# Altium schematic sheet Voltage Regulator.SchDoc
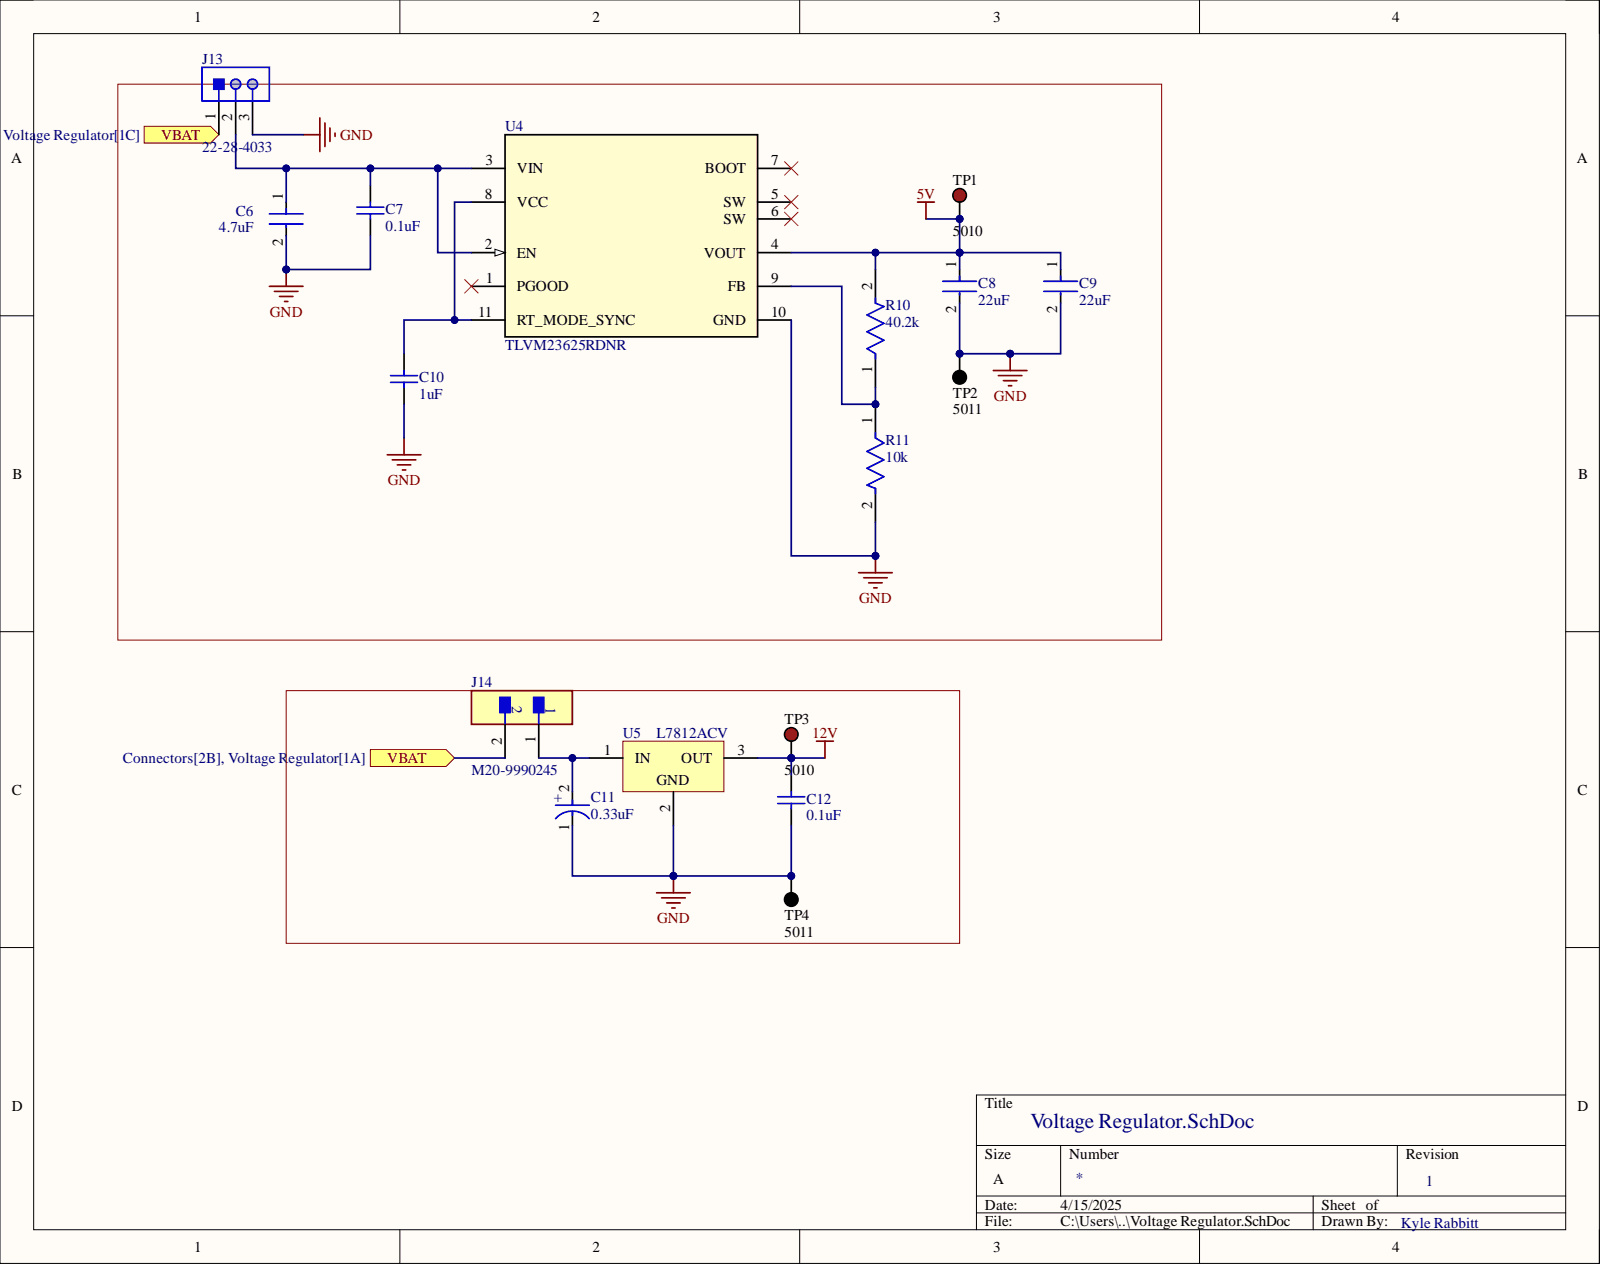
[diagram p0: Voltage Regulator.SchDoc]

## Parts
C6  4.7uF  [FP-C1210X475K5RACTU-MFG]
C7  0.1uF  [CAPC1608X90X35ML10T15]
C8  22uF  [FP-1210-L_3_3_0_4-W_2_5_0-MFG]
C9  22uF  [FP-1210-L_3_3_0_4-W_2_5_0-MFG]
C10  1uF  [CAPC2013X145X50LL20T25]
C11  0.33uF  [FP-TAJS-MFG]
C12  0.1uF  [CAPC1608X90X35ML10T15]
J13  22-28-4033  [MOLX-22-28-4033_V]
J14  M20-9990245  [FP-M20-9990245-MFG]
R10  40.2k  [FP-CRCW0603-e3-IPC_B]
R11  10k  [FP-MCT0603-IPC_C]
TP1  5010  [KSTN-5010_V]
TP2  5011  [KSTN-5011_V]
TP3  5010  [KSTN-5010_V]
TP4  5011  [KSTN-5011_V]
U4  TLVM23625RDNR  [RDN0011A-MFG]
U5  L7812ACV  [TO220]

## Ports
VBAT

## Nets
5V: C8.1 C9.1 R10.2 TP1.1 U4.4(VOUT)
12V: C12.2 TP3.1 U5.3
GND: C6.2 C7.1 C8.2 C9.2 C10.1 C11.1 C12.1 J13.3 R11.2 TP2.1 TP4.1 U4.10(GND) U5.2
VBAT: J13.1 J14.2 ; port VBAT
NetC6_1: C6.1 C7.2 J13.2 U4.2(EN) U4.3(VIN)
NetC10_2: C10.2 U4.8(VCC) U4.11(RT_MODE_SYNC)
NetC11_2: C11.2 J14.1 U5.1
NetR10_1: R10.1 R11.1 U4.9(FB)

Not connected: U4 1(PGOOD) 5(SW) 6(SW) 7(BOOT)

## Library data of the parts
C6 = CMP-2000-06022-2: CAP CER 4.7UF 50V X7R 1210; Dielectric Material: Ceramic; Dissipation Factor: 2.5%; Tolerance: 10%; Capacitance: 4.7uF; Case Code (Imperial): 1210; Case Code (Metric): 3225; Case/Package: 1210; Component Kind: Standard; Construction: Flat; Depth: 2.5mm; Dielectric: X7R; Features: Soft Termination; Height: 2.5mm; Length: 3.3mm; Library Name: Altium Content Vault; Max Operating Temperature: 125°C; Min Operating Temperature: -55°C; Mount: Surface Mount; Number of Pins: 2; Package Quantity: 1000; Packaging: Tape and Reel; Radiation Hardening: No; REACH SVHC: No SVHC; RoHS Compliant: Yes; Series: FT-CAP; Termination: SMD/SMT; Thickness: 0.11inch; Voltage: 50V; Voltage Rating: 50V; Voltage Rating (DC): 50V; Width: 2.5mm
C7 C12 = CMP-2100-03643-1: Chip Capacitor, 0.1 uF, +/- 10%, 50 V, -55 to 125 degC, 0603 (1608 Metric), RoHS, Tape and Reel; Manufacturer: Murata; Capacitance: 100nF; Dielectric: X7R; Dielectric Material: Ceramic; Insulation Resistance: 10GR; Voltage: 50V; Case Code (Imperial): 0603; Case Code (Metric): 1608; Case/Package: 0603; Component Kind: Standard; Contact Plating: Tin; Datasheet URL: http://psearch.en.murata.com/capacitor/product/GRM188R71H104KA93%23.pdf; Datasheet Version: 42675.0; Depth: 0.8mm; Height: 0.8mm; Lead Free: LeadFree; Length: 1.6mm; Library Name: Altium Content Vault; Manufacturer URL: http://www.murata.com/; Material: Ceramic; Max Operating Temperature: 125degC; Min Operating Temperature: -55degC; Mount: SurfaceMount; Number of Pins: 2; Package Description: 2-Pin Surface Mount Device, Body 1.6 x 0.8 mm; Package Quantity: 1; Package Reference: 0603; Packaging: TapeandReel; Radiation Hardening: No; REACH SVHC: NoSVHC; RoHSCompliant: true; Termination: SMD/SMT; Thickness: 0.035inch; Tolerance: 10%; Voltage Rating: 50V; Voltage Rating (DC): 50V; Width: 0.8mm
C8 C9 = CMP-2000-05483-2: General Purpose Ceramic Capacitor, 1210, 22uF, 10%, X5R, 15%, 16V; Capacitance: 22uF; Case Code (Imperial): 1210; Case Code (Metric): 3225; Case/Package: 1210; Component Kind: Standard; Construction: Flat; Contact Plating: Tin; Depth: 2.5mm; Dielectric: X5R; Height: 2.79mm; Lead Free: Lead Free; Length: 3.2mm; Library Name: Altium Content Vault; Max Operating Temperature: 85°C; Min Operating Temperature: -55°C; Mount: Surface Mount; Number of Pins: 2; Package Quantity: 1; Packaging: Tape and Reel; Radiation Hardening: No; REACH SVHC: No SVHC; RoHS Compliant: Yes; Series: -; Size: 2.79mm; Termination: SMD/SMT; Thickness: 0.11inch; Tolerance: 10%; Voltage: 16V; Voltage Rating: 16V; Voltage Rating (DC): 16V; Weight: 0.000564oz; Width: 0.098inch
C10 = CMP-1036-04746-2: Manufacturer: Kemet; Part Number: C0805C105K4PACTU; Component Kind: Standard; Library Name: Altium Content Vault
C11 = CMP-04424-000233-1: 330nF 35V  ±10% 15Ω 1206  SMD Tantalum Capacitor; Dissipation Factor: 4%; ESR (Equivalent Series Resistance): 15R; Leakage Current: 500nA; Polarity: Polar; Capacitance: 330nF; Case Code (Imperial): 1206; Case Code (Metric): 3216; Case/Package: 1206; Component Kind: Standard; Construction: Flat; Depth: 1.6mm; Dielectric Material: Tantalum; Features: General Purpose; Height: 1.2mm; Height - Seated (Max): 0.047inch; Lead Free: Lead Free; Lead Pitch: 1.1mm; Length: 3.2mm; Library Name: Altium Content Vault; Max Operating Temperature: 125°C; Min Operating Temperature: -55°C; Mount: Surface Mount; Number of Pins: 2; Package Quantity: 2500; Packaging: Tape and Reel; Radiation Hardening: No; REACH SVHC: No SVHC; RoHS Compliant: Yes; Series: TAJ; Termination: SMD/SMT; Tolerance: 10%; Voltage Rating: 35V; Voltage Rating (DC): 35V; Weight: 0.000571oz; Width: 1.6mm
J13 = CMP-1676-00001-5: Male Header, Pitch 2.54 mm, 1 x 3 Position, Height 8.38 mm, Tail Length 3.18 mm, RoHS, Bulk; Manufacturer: Molex; Current Rating: 4 A; Rows: 1; Voltage Rating: 250 V; Colour: Black; Component Kind: Standard; ComponentLink1Description: Manufacturer URL; ComponentLink1URL: http://www.molex.com/; ComponentLink2Description: Datasheet; ComponentLink2URL: http://www.molex.com/pdm_docs/sd/022284033_sd.pdf; Contact Finish: Gold; Contact Material: Brass; Contact Plating: Gold; DatasheetVersion: Rev. G, 01/2012; Height: 8.38 mm; Lead Pitch: 0.1 inch; Length: 7.62 mm; Library Name: Altium Content Vault; Max Operating Temperature: 75 degC; Min Operating Temperature: 0 degC; Mount: Through Hole; Mounting Technology: Through Hole; Package Quantity: 1; PackageDescription: Male Header, Pitch 2.54 mm, 1 x 3 Position, Height 8.38 mm, Tail Length 3.18 mm; PackageReference: 22-28-4033; Packaging: Bulk; Pins: 3; REACH SVHC: Unknown; RoHSCompliant: true
J14 = CMP-15831-000105-1: CONN HEADER VERT 2POS 2.54MM; Connector Type: Male Pin; Contact Resistance: 25mR; Current: 3A; Gender: Male; Insulation Resistance: 10GR; Mated Stacking Heights: 16.54mm; Mating Cycles: 300; Number of Contacts: 2; Voltage: 50V; Voltage Rating (AC): 750V; Case/Package: SIL; Color: Black; Component Kind: Standard; Contact Finish - Mating: GOLD; Contact Material: Brass; Contact Mating Length: 0.24inch; Contact Plating: Gold; Contact Shape: Square; Contact Type: Male Pin; Current Rating: 3A; Depth: 2.54mm; Fastening Type: Pull; Flammability Rating: UL94 V-0; Height: 0.12inch; Housing Color: Black; Housing Material: Nylon; Insulation Color: Black; Insulation Material: Nylon; Lead Pitch: 2.54mm; Length: 5.08mm; Library Name: Altium Content Vault; Max Current Rating: 3A; Max Operating Temperature: 105°C; Min Operating Temperature: -40°C; Mount: Through Hole; Number of Poles: 2; Number of Positions: 2; Number of Rows: 1; Orientation: Straight; Package Quantity: 1000; Packaging: Bulk; Pitch: 2.54mm; Radiation Hardening: No; Reach SVHC Compliant: Yes; Series: M20; Termination: Solder; Weight: 0.25lb; Width: 0.25inch; Working Voltage: 750V
R10 = CMP-2000-03336-2: RES Thick Film, 40.2kΩ, 1%, 0.1W, 100ppm/°C, 0603; Power Rating: 100mW; Resistance: 40.2kR; Voltage Rating: 75V; Case Code (Imperial): 0603; Case Code (Metric): 1608; Case/Package: 0603; Component Kind: Standard; Composition: Thick Film; Contact Plating: Tin; Depth: 0.85mm; Height: 0.45mm; Lead Free: Lead Free; Length: 1.55mm; Library Name: Altium Content Vault; Max Operating Temperature: 155°C; Military Standard: Not; Min Operating Temperature: -55°C; Package Quantity: 5000; Packaging: Tape and Reel; Radiation Hardening: No; Temperature Coefficient: 100ppm/°C; Termination: SMD/SMT; Tolerance: 1%; Voltage Rating (DC): 75V; Weight: 7.1E-05oz; Width: 0.85mm
R11 = CMP-02407-000418-1: RES SMD 100 OHM 1% 1/8W 0603; Max Power Dissipation: 125mW; Power Rating: 100mW; Resistance: 10kR; Temperature Coefficient: 50ppm/°C; Voltage Rating: 75V; Case Code (Imperial): 0603; Case Code (Metric): 1608; Case/Package: 0603; Component Kind: Standard; Composition: Thin Film; Contact Plating: Tin; Depth: 0.85mm; Height: 0.022inch; Lead Free: Lead Free; Length: 1.55mm; Library Name: Altium Content Vault; Max Operating Temperature: 125°C; Military Standard: Not; Min Operating Temperature: -55°C; Mount: Surface Mount; Number of Terminations: 2; Package Quantity: 1; Packaging: Tape and Reel; Radiation Hardening: No; Tolerance: 1%; Voltage Rating (DC): 75V; Width: 0.8mm
TP1 TP3 = CMP-1672-00002-4: Test Point, Red, Through Hole, RoHS, Bulk; Manufacturer: Keystone; Colour: Red; Component Kind: Standard; ComponentLink1Description: Manufacturer URL; ComponentLink1URL: http://www.keyelco.com/; ComponentLink2Description: Datasheet; ComponentLink2URL: http://www.keyelco.com/product-pdf.cfm?p=1319; Contact Material: Bronze; Contact Plating: Silver; DatasheetVersion: Rev. F, 12/2013; Height: 8.12 mm; Library Name: Altium Content Vault; Mount: Through Hole; Mounting Technology: Through Hole; Package Quantity: 100; PackageDescription: 1-Pin Through Hole Device, Dia 3.17 mm; PackageReference: 5010; Packaging: Bulk; Pins: 1; REACH SVHC: No SVHC; RoHSCompliant: true
TP2 TP4 = CMP-1672-00003-4: Test Point, Black, Through Hole, RoHS, Bulk; Manufacturer: Keystone; Colour: Black; Component Kind: Standard; ComponentLink1Description: Manufacturer URL; ComponentLink1URL: http://www.keyelco.com/; ComponentLink2Description: Datasheet; ComponentLink2URL: http://www.keyelco.com/product-pdf.cfm?p=1320; Contact Material: Bronze; Contact Plating: Silver; DatasheetVersion: Rev. F, 12/2013; Height: 8.12 mm; Library Name: Altium Content Vault; Mount: Through Hole; Mounting Technology: Through Hole; Package Quantity: 100; PackageDescription: 1-Pin Through Hole Device, Dia 3.17 mm; PackageReference: 5011; Packaging: Bulk; Pins: 1; REACH SVHC: No SVHC; RoHSCompliant: true
U4 = TLVM23625RDNR: No Description Available; Mfr_Name: Texas Instruments; Component Kind: Standard; Library Name: TLVM23625RDNR.IntLib; RefDes: RefDes; TYPE: TYPE
U5 = CMP-0244-00451-1: Positive Voltage Regulator, 12V, 3-Pin TO-220; Component Kind: Standard; ComponentLink1Description: Manufacturer URL; ComponentLink1URL: http://www.st.com; ComponentLink2Description: Datasheet; ComponentLink2URL: http://www.st.com/internet/com/TECHNICAL_RESOURCES/TECHNICAL_LITERATURE/DATASHEET/CD00000444.pdf; ComponentLink3Description: Package Specification; ComponentLink3URL: http://www.st.com/internet/com/TECHNICAL_RESOURCES/TECHNICAL_LITERATURE/PACKAGE_INFORMATION/CD00004649.pdf; DatasheetVersion: 16/11/2011; Library Name: Altium Content Vault; PackageDescription: TO, Thru-Hole, Vertical, Heatsink Mounted; 3 In-Line Leads; Pitch 2.54 mm; PackageReference: TO220; PackageVersion: ver3.0
